# Altium schematic sheet 4.MCU.SchDoc
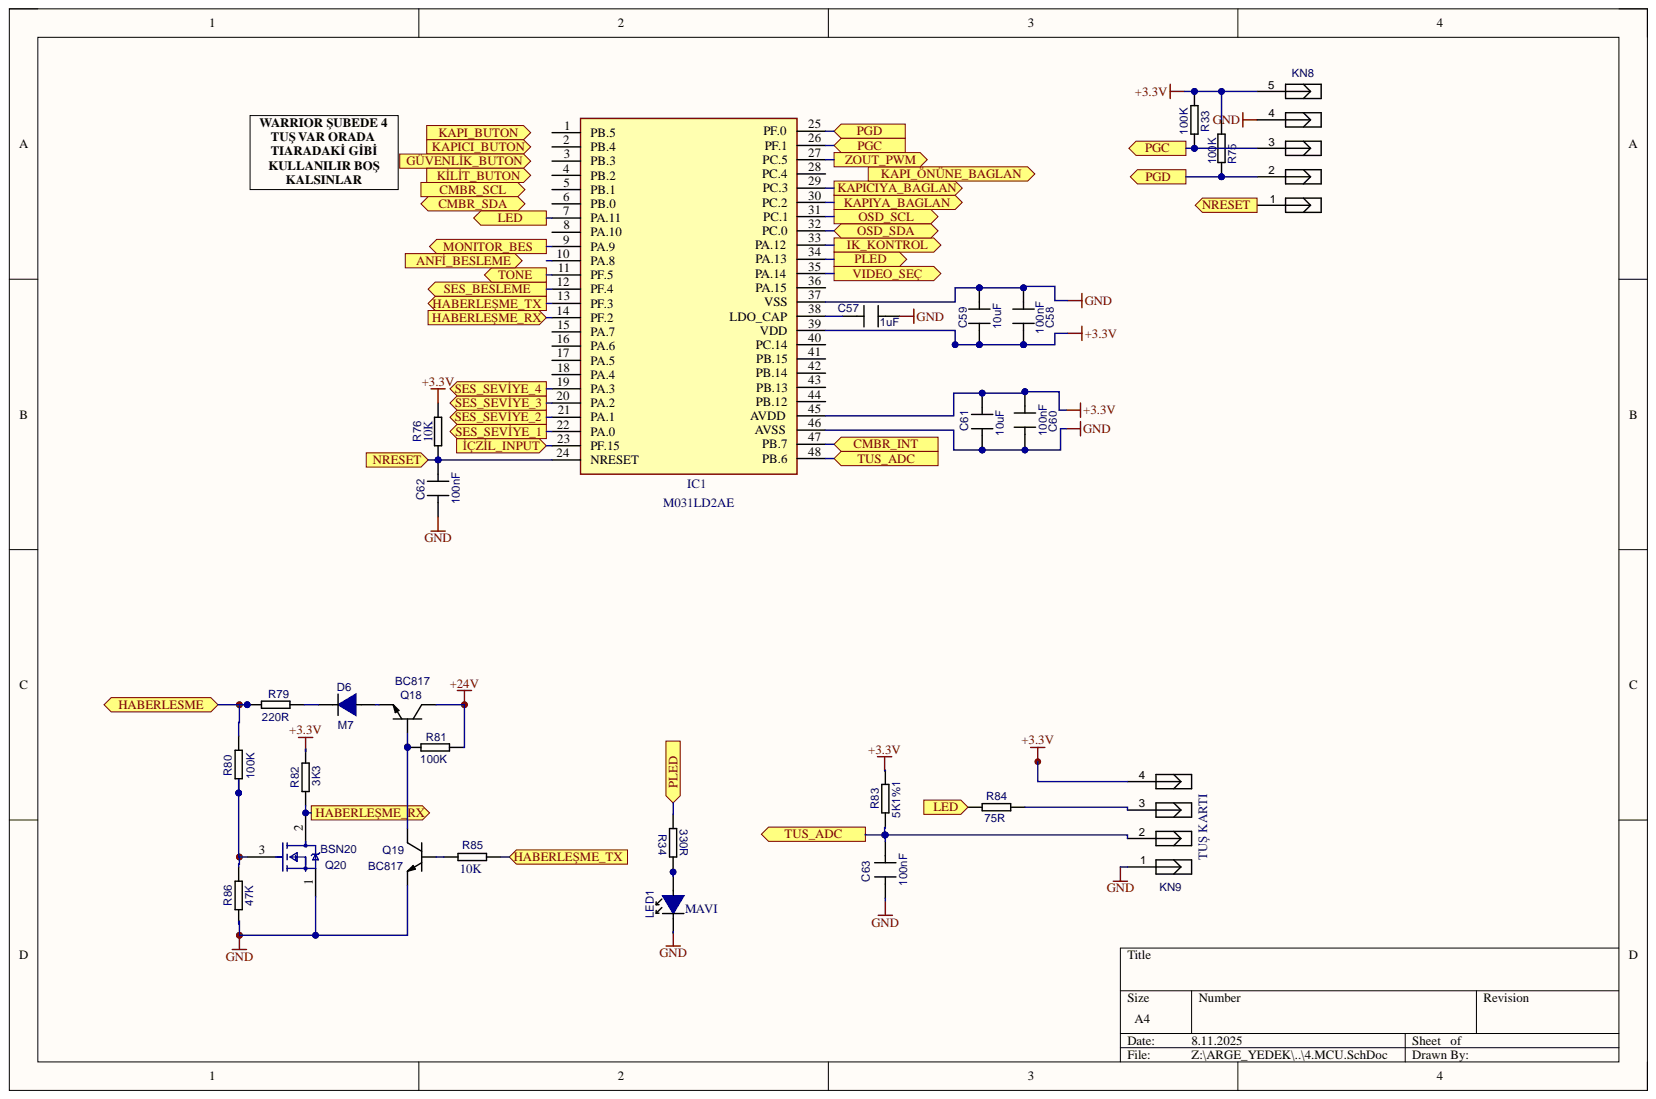
[diagram p0: 4.MCU.SchDoc]

## Parts
C57  value 1uF  [IPC_1206]  (hidden comment: KOND 1UF 50V %10 1206 X7R SMD)
C58  value 100nF  [IPC_1206]  (hidden comment: KOND 100NF 50V %10 X7R 1206 SMD)
C59  value 10uF  [IPC_1206]  (hidden comment: KOND 10UF 50V %10 X7R 1206 SMD)
C60  value 100nF  [IPC_1206]  (hidden comment: KOND 100NF 50V %10 X7R 1206 SMD)
C61  value 10uF  [IPC_1206]  (hidden comment: KOND 10UF 50V %10 X7R 1206 SMD)
C62  value 100nF  [IPC_1206]  (hidden comment: KOND 100NF 50V %10 X7R 1206 SMD)
C63  value 100nF  [IPC_1206]  (hidden comment: KOND 100NF 50V %10 X7R 1206 SMD)
D6  value M7  [IPC_MELF]  (hidden comment: DIYOT 1N4007(M7) 1A DO-214AC SMD)
IC1  value M031LD2AE  [LQFP48]  (hidden comment: IC M031LD2AE LQFP48)
KN8  KONN 5LI ERKEK DIK 2.5MM TH  [EKINLER_391805]  (value not drawn)
KN9  KONN 4LU ERKEK YATIK 2MM TH  [KONN 4LU ERKEK YATIK 2MM]  (value not drawn)
LED1  value MAVI  [LED1206]  (hidden comment: LED MAVI 1206 SMD)
Q18  value BC817  [IPC_TRANSISTOR]  (hidden comment: TRAN BC817 NPN 45V 0.5A SOT23 SMD)
Q19  value BC817  [IPC_TRANSISTOR]  (hidden comment: TRAN BC817 NPN 45V 0.5A SOT23 SMD)
Q20  value BSN20  [IPC_N_MOSFET_1]  (hidden comment: MOSFET BSN20 0.1A 50V N CHAN. SOT23 SMD)
R33  value 100K  [IPC_1206]  (hidden comment: DIRENC 100K %5 1206 1/4W)
R34  value 330R  [IPC_1206]  (hidden comment: DIRENC 330R %5 1206 1/4W)
R75  value 100K  [IPC_1206]  (hidden comment: DIRENC 100K %5 1206 1/4W)
R76  value 10K  [IPC_1206]  (hidden comment: DIRENC 10K %5 1206 1/4W)
R79  value 220R  [IPC_1206]  (hidden comment: DIRENC 220R %5 1206 1/4W)
R80  value 100K  [IPC_1206]  (hidden comment: DIRENC 100K %5 1206 1/4W)
R81  value 100K  [IPC_1206]  (hidden comment: DIRENC 100K %5 1206 1/4W)
R82  value 3K3  [IPC_1206]  (hidden comment: DIRENC 3K3 %5 1206 1/4W)
R83  value 5K1%1  [IPC_1206]  (hidden comment: DIRENC 5K1 %1 1206 1/4W)
R84  value 75R  [IPC_1206]  (hidden comment: DIRENC 75R %5 1206 1/4W)
R85  value 10K  [IPC_1206]  (hidden comment: DIRENC 10K %5 1206 1/4W)
R86  value 47K  [IPC_1206]  (hidden comment: DIRENC 47K %5 1206 1/4W)

## Ports
ANFİ_BESLEME, CMBR_INT, CMBR_SCL, CMBR_SDA, GÜVENLİK_BUTON, HABERLESME, HABERLEŞME_RX, HABERLEŞME_TX, IK_KONTROL, İÇZİL_INPUT, KAPI_BUTON, KAPI_ÖNÜNE_BAGLAN, KAPICI_BUTON, KAPICIYA_BAGLAN, KAPIYA_BAGLAN, KİLİT_BUTON, LED, MONITOR_BES, NRESET, OSD_SCL, OSD_SDA, PGC, PGD, PLED, SES_BESLEME, SES_SEVİYE_1, SES_SEVİYE_2, SES_SEVİYE_3, SES_SEVİYE_4, TONE, TUS_ADC, VIDEO_SEÇ, ZOUT_PWM

## Nets
+3.3V: C58.1 C59.1 C60.2 C61.2 IC1.39(VDD) IC1.45(AVDD) KN8.5 KN9.4 R33.1 R75.1 R76.1 R82.2 R83.1
+24V: Q18.3 R81.1
GND: C57.2 C58.2 C59.2 C60.1 C61.1 C62.1 C63.2 IC1.37(VSS) IC1.46(AVSS) KN8.4 KN9.1 LED1.1 Q19.2 Q20.1 R86.1
CMBR_INT: IC1.47(PB.7) ; port CMBR_INT
HABERLESME: R79.1 R80.2 ; port HABERLESME
HABERLEŞME_RX: IC1.14(PF.2) Q20.2 R82.1 ; port HABERLEŞME_RX
HABERLEŞME_TX: IC1.13(PF.3) R85.1 ; port HABERLEŞME_TX
IK_KONTROL: IC1.33(PA.12) ; port IK_KONTROL
İÇZİL_INPUT: IC1.23(PF.15) ; port İÇZİL_INPUT
KAPICIYA_BAGLAN: IC1.29(PC.3) ; port KAPICIYA_BAGLAN
KAPIYA_BAGLAN: IC1.30(PC.2) ; port KAPIYA_BAGLAN
LED: IC1.7(PA.11) R84.1 ; port LED
MONITOR_BES: IC1.9(PA.9) ; port MONITOR_BES
NRESET: C62.2 IC1.24(NRESET) KN8.1 R76.2 ; port NRESET
OSD_SCL: IC1.31(PC.1) ; port OSD_SCL
OSD_SDA: IC1.32(PC.0) ; port OSD_SDA
PGC: IC1.26(PF.1) KN8.3 R33.2 ; port PGC
PGD: IC1.25(PF.0) KN8.2 R75.2 ; port PGD
PLED: IC1.34(PA.13) R34.1 ; port PLED
SES_BESLEME: IC1.12(PF.4) ; port SES_BESLEME
SES_SEVİYE_1: IC1.22(PA.0) ; port SES_SEVİYE_1
SES_SEVİYE_2: IC1.21(PA.1) ; port SES_SEVİYE_2
SES_SEVİYE_3: IC1.20(PA.2) ; port SES_SEVİYE_3
SES_SEVİYE_4: IC1.19(PA.3) ; port SES_SEVİYE_4
TONE: IC1.11(PF.5) ; port TONE
TUS_ADC: C63.1 IC1.48(PB.6) KN9.2 R83.2 ; port TUS_ADC
VIDEO_SEÇ: IC1.35(PA.14) ; port VIDEO_SEÇ
ZOUT_PWM: IC1.27(PC.5) ; port ZOUT_PWM
NetC57_1: C57.1 IC1.38(LDO_CAP)
NetD6_1: D6.1 R79.2
NetD6_2: D6.2 Q18.2
NetKN9_3: KN9.3 R84.2
NetLED1_2: LED1.2 R34.2
NetQ18_1: Q18.1 Q19.3 R81.2
NetQ19_1: Q19.1 R85.2
NetQ20_3: Q20.3 R80.1 R86.2
ANFİ_BESLEME: ; port ANFİ_BESLEME
CMBR_SCL: ; port CMBR_SCL
CMBR_SDA: ; port CMBR_SDA
GÜVENLİK_BUTON: ; port GÜVENLİK_BUTON
KAPI_BUTON: ; port KAPI_BUTON
KAPI_ÖNÜNE_BAGLAN: ; port KAPI_ÖNÜNE_BAGLAN
KAPICI_BUTON: ; port KAPICI_BUTON
KİLİT_BUTON: ; port KİLİT_BUTON

Not connected: IC1 1(PB.5) 2(PB.4) 3(PB.3) 4(PB.2) 5(PB.1) 6(PB.0) 8(PA.10) 10(PA.8) 15(PA.7) 16(PA.6) 17(PA.5) 18(PA.4) 28(PC.4) 36(PA.15) 40(PC.14) 41(PB.15) 42(PB.14) 43(PB.13) 44(PB.12)

## Library data of the parts
C57 = KOND 1UF 50V %10 1206 X7R SMD: Stok_no: H.E.KON.00092; Component Kind: Standard; Montaj_tipi: SMD; Library Name: HEKON.SchLib
C58 C60 C62 C63 = KOND 100NF 50V %10 X7R 1206 SMD: Stok_no: H.E.KON.00060; Component Kind: Standard; Montaj_tipi: SMD; Library Name: HEKON.SchLib
C59 C61 = KOND 10UF 50V %10 X7R 1206 SMD: Stok_no: H.E.KON.00118; Component Kind: Standard; Montaj_tipi: SMD; Library Name: HEKON.SchLib
D6 = DIYOT 1N4007(M7) 1A DO-214AC SMD: Stok_no: H.E.DIY.00006; Component Kind: Standard; Montaj_tipi: SMD; Library Name: HEDIY.SchLib
IC1 = IC M031LD2AE LQFP48: 32-Bit 64KB Flash and 8KB SRAM; Montaj_tipi: SMD; Stok_no: H.E.ENT.00184; Component Kind: Standard; Library Name: HEENT.SchLib
KN8 = KONN 5LI ERKEK DIK 2.5MM TH: Stok_no: H.E.KNN.00020; Value: 5LI_ERKEK_DIK; Component Kind: Standard; Montaj_tipi: TH; Library Name: HEKNN.SchLib
KN9 = KONN 4LU ERKEK YATIK 2MM TH: Stok_no: H.E.KNN.00070; Value: 4LU_ERKEK_YATIK; Component Kind: Standard; Montaj_tipi: TH; Library Name: HEKNN.SchLib
LED1 = LED MAVI 1206 SMD: Stok_no: H.E.LED.00014; Component Kind: Standard; Montaj_tipi: SMD; Library Name: HELED.SchLib
Q18 Q19 = TRAN BC817 NPN 45V 0.5A SOT23 SMD: Stok_no: H.E.TRA.00012; Component Kind: Standard; Montaj_tipi: SMD; Library Name: HETRA.SchLib
Q20 = MOSFET BSN20 0.1A 50V N CHAN. SOT23 SMD: Stok_no: H.E.TRA.00019; Component Kind: Standard; Montaj_tipi: SMD; Library Name: HETRA.SchLib
R33 R75 R80 R81 = DIRENC 100K %5 1206 1/4W: Montaj_tipi: SMD; Stok_no: H.E.DIR.00105; Component Kind: Standard; Library Name: HEDIR.SchLib
R34 = DIRENC 330R %5 1206 1/4W: Montaj_tipi: SMD; Stok_no: H.E.DIR.00136; Component Kind: Standard; Library Name: HEDIR.SchLib
R76 R85 = DIRENC 10K %5 1206 1/4W: Montaj_tipi: SMD; Stok_no: H.E.DIR.00130; Component Kind: Standard; Library Name: HEDIR.SchLib
R79 = DIRENC 220R %5 1206 1/4W: Montaj_tipi: SMD; Stok_no: H.E.DIR.00157; Component Kind: Standard; Library Name: HEDIR.SchLib
R82 = DIRENC 3K3 %5 1206 1/4W: Montaj_tipi: SMD; Stok_no: H.E.DIR.00125; Component Kind: Standard; Library Name: HEDIR.SchLib
R83 = DIRENC 5K1 %1 1206 1/4W: Montaj_tipi: SMD; Stok_no: H.E.DIR.00103; Component Kind: Standard; Library Name: HEDIR.SchLib
R84 = DIRENC 75R %5 1206 1/4W: Montaj_tipi: SMD; Stok_no: H.E.DIR.00180; Component Kind: Standard; Library Name: HEDIR.SchLib
R86 = DIRENC 47K %5 1206 1/4W: Montaj_tipi: SMD; Stok_no: H.E.DIR.00155; Component Kind: Standard; Library Name: HEDIR.SchLib
